# Altium schematic sheet 05-SX1276.SchDoc(05-SX1276)
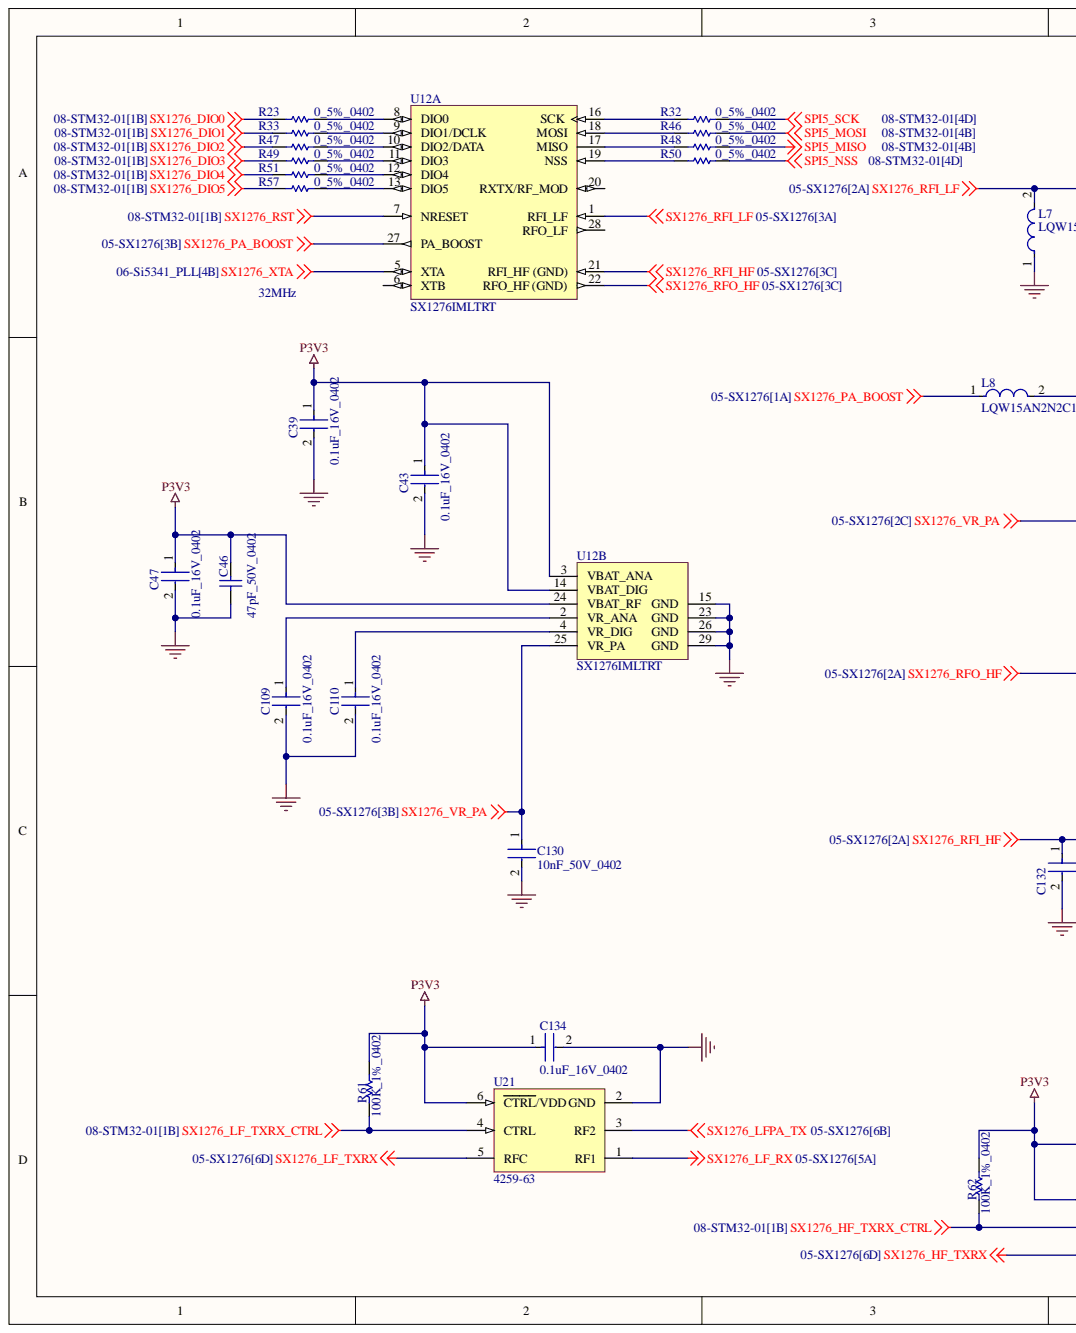
[diagram p0: 05-SX1276.SchDoc, left]
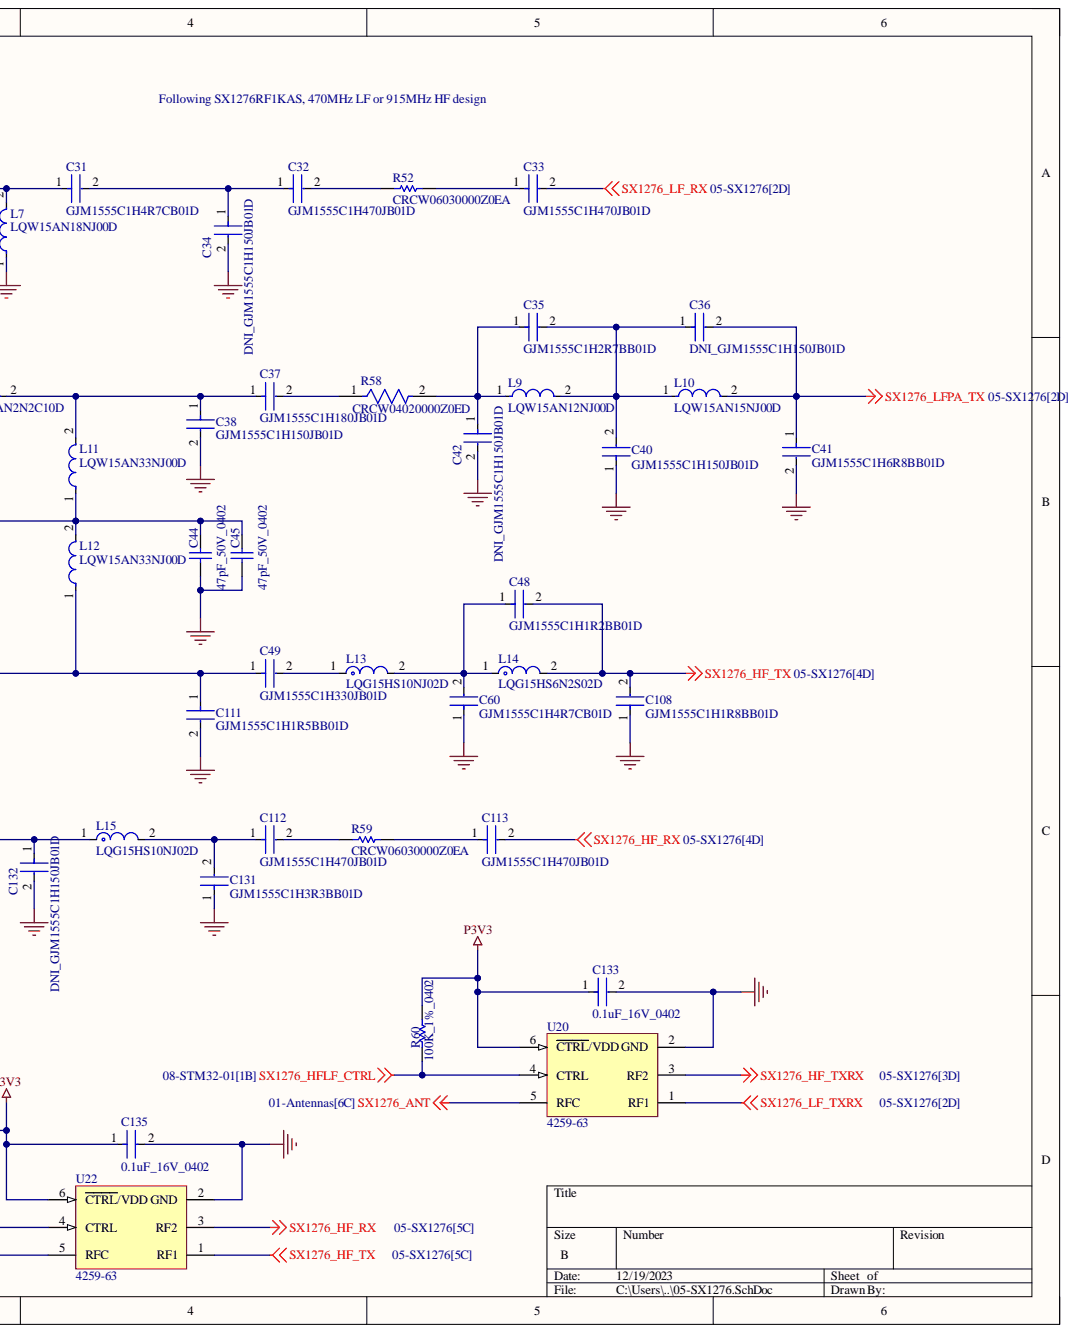
[diagram p1: 05-SX1276.SchDoc, right]

## Parts
C31  GJM1555C1H4R7CB01D  [FP-GJM155-0_05-IPC_B]
C32  GJM1555C1H470JB01D  [FP-GJM155-0_05-MFG]
C33  GJM1555C1H470JB01D  [FP-GJM155-0_05-MFG]
C34  DNI_GJM1555C1H150JB01D  [FP-GJM155-0_05-IPC_A]  (no BOM)
C35  GJM1555C1H2R7BB01D  [FP-GJM155-0_05-IPC_A]
C36  DNI_GJM1555C1H150JB01D  [FP-GJM155-0_05-IPC_A]  (no BOM)
C37  GJM1555C1H180JB01D  [FP-GJM155-0_05-IPC_B]
C38  GJM1555C1H150JB01D  [FP-GJM155-0_05-IPC_A]
C39  0.1uF_16V_0402  [FP-C0402-BB-MFG]
C40  GJM1555C1H150JB01D  [FP-GJM155-0_05-IPC_A]
C41  GJM1555C1H6R8BB01D  [FP-GJM155-0_05-IPC_A]
C42  DNI_GJM1555C1H150JB01D  [FP-GJM155-0_05-IPC_A]  (no BOM)
C43  0.1uF_16V_0402  [FP-C0402-BB-MFG]
C44  47pF_50V_0402  [CAPC1005X55X25LL05T10]
C45  47pF_50V_0402  [CAPC1005X55X25LL05T10]
C46  47pF_50V_0402  [CAPC1005X55X25LL05T10]
C47  0.1uF_16V_0402  [FP-C0402-BB-MFG]
C48  GJM1555C1H1R2BB01D  [FP-GJM155-0_05-IPC_B]
C49  GJM1555C1H330JB01D  [FP-GJM155-0_05-MFG]
C60  GJM1555C1H4R7CB01D  [FP-GJM155-0_05-IPC_B]
C108  GJM1555C1H1R8BB01D  [FP-GJM155-0_05-IPC_B]
C109  0.1uF_16V_0402  [FP-C0402-BB-MFG]
C110  0.1uF_16V_0402  [FP-C0402-BB-MFG]
C111  GJM1555C1H1R5BB01D  [FP-GJM155-0_05-IPC_C]
C112  GJM1555C1H470JB01D  [FP-GJM155-0_05-MFG]
C113  GJM1555C1H470JB01D  [FP-GJM155-0_05-MFG]
C130  10nF_50V_0402  [FP-CL165-IPC_C]
C131  GJM1555C1H3R3BB01D  [FP-GJM155-0_05-IPC_C]
C132  DNI_GJM1555C1H150JB01D  [FP-GJM155-0_05-IPC_A]  (no BOM)
C133  0.1uF_16V_0402  [FP-C0402-BB-MFG]
C134  0.1uF_16V_0402  [FP-C0402-BB-MFG]
C135  0.1uF_16V_0402  [FP-C0402-BB-MFG]
L7  LQW15AN18NJ00D  [FP-LQW15AN_00-W0_6-0_1-MFG]
L8  LQW15AN2N2C10D  [FP-LQW15AN_00-W0_6-0_1-MFG]
L9  LQW15AN12NJ00D  [FP-LQW15AN_00-W0_6-0_1-MFG]
L10  LQW15AN15NJ00D  [FP-LQW15AN_00-W0_6-0_1-MFG]
L11  LQW15AN33NJ00D  [FP-LQW15AN_00-W0_5-0_1-MFG]
L12  LQW15AN33NJ00D  [FP-LQW15AN_00-W0_5-0_1-MFG]
L13  LQG15HS10NJ02D  [FP-LQG15HH_02-IPC_A]
L14  LQG15HS6N2S02D  [FP-LQG15HH_02-IPC_C]
L15  LQG15HS10NJ02D  [FP-LQG15HH_02-IPC_A]
R23  0_5%_0402  [RESC1005X40X25NL05T10]
R32  0_5%_0402  [RESC1005X40X25NL05T10]
R33  0_5%_0402  [RESC1005X40X25NL05T10]
R46  0_5%_0402  [RESC1005X40X25NL05T10]
R47  0_5%_0402  [RESC1005X40X25NL05T10]
R48  0_5%_0402  [RESC1005X40X25NL05T10]
R49  0_5%_0402  [RESC1005X40X25NL05T10]
R50  0_5%_0402  [RESC1005X40X25NL05T10]
R51  0_5%_0402  [RESC1005X40X25NL05T10]
R52  CRCW06030000Z0EA  [RESC1609X50X30NL8T20]
R57  0_5%_0402  [RESC1005X40X25NL05T10]
R58  CRCW04020000Z0ED  [FP-CRCW0402-e3-IPC_C]
R59  CRCW06030000Z0EA  [RESC1609X50X30NL8T20]
R60  100K_1%_0402  [RESC1005X40X25ML05T10]
R61  100K_1%_0402  [RESC1005X40X25ML05T10]
R62  100K_1%_0402  [RESC1005X40X25ML05T10]
U12  SX1276IMLTRT  [SMTC-QFN-28_V]  (parts A,B)
U20  4259-63  [PERE-SC-70-6_M]
U21  4259-63  [PERE-SC-70-6_M]
U22  4259-63  [PERE-SC-70-6_M]

## Nets
GND: C34.2 C38.2 C39.2 C40.1 C41.2 C42.2 C43.2 C44.1 C45.1 C46.1 C47.2 C60.1 C108.1 C109.2 C110.2 C111.2 C130.2 C131.1 C132.2 C133.2 C134.2 C135.2 L7.1 U12.15(GND) U12.23(GND) U12.26(GND) U12.29(GND) U20.2(GND) U21.2(GND) U22.2(GND)
P3V3: C39.1 C43.1 C46.2 C47.1 C133.1 C134.1 C135.1 R60.2 R61.2 R62.2 U12.3(VBAT_ANA) U12.14(VBAT_DIG) U12.24(VBAT_RF) U20.6(CTRL/VDD) U21.6(CTRL/VDD) U22.6(CTRL/VDD)
SPI5_MISO: R48.2
SPI5_MOSI: R46.2
SPI5_NSS: R50.2
SPI5_SCK: R32.2
SX1276_ANT: U20.5(RFC)
SX1276_DIO0: R23.1
SX1276_DIO1: R33.1
SX1276_DIO2: R47.1
SX1276_DIO3: R49.1
SX1276_DIO4: R51.1
SX1276_DIO5: R57.1
SX1276_HF_RX: C113.2 U22.3(RF2)
SX1276_HF_TX: C48.2 C108.2 L14.2 U22.1(RF1)
SX1276_HF_TXRX: U20.3(RF2) U22.5(RFC)
SX1276_HF_TXRX_CTRL: R62.1 U22.4(CTRL)
SX1276_HFLF_CTRL: R60.1 U20.4(CTRL)
SX1276_LF_RX: C33.2 U21.1(RF1)
SX1276_LF_TXRX: U20.1(RF1) U21.5(RFC)
SX1276_LF_TXRX_CTRL: R61.1 U21.4(CTRL)
SX1276_LFPA_TX: C36.2 C41.1 L10.2 U21.3(RF2)
SX1276_PA_BOOST: L8.1 U12.27(PA_BOOST)
SX1276_RFI_HF: C132.1 L15.1 U12.21(RFI_HF_(GND))
SX1276_RFI_LF: C31.1 L7.2 U12.1(RFI_LF)
SX1276_RFO_HF: C49.1 C111.1 L12.1 U12.22(RFO_HF_(GND))
SX1276_RST: U12.7(NRESET)
SX1276_VR_PA: C44.2 C45.2 C130.1 L11.1 L12.2 U12.25(VR_PA)
SX1276_XTA: U12.5(XTA)
NetC31_2: C31.2 C32.1 C34.1
NetC32_2: C32.2 R52.1
NetC33_1: C33.1 R52.2
NetC35_1: C35.1 C42.1 L9.1 R58.2
NetC35_2: C35.2 C36.1 C40.2 L9.2 L10.1
NetC37_1: C37.1 C38.1 L8.2 L11.2
NetC37_2: C37.2 R58.1
NetC48_1: C48.1 C60.2 L13.2 L14.1
NetC49_2: C49.2 L13.1
NetC109_1: C109.1 U12.2(VR_ANA)
NetC110_1: C110.1 U12.4(VR_DIG)
NetC112_1: C112.1 C131.2 L15.2
NetC112_2: C112.2 R59.1
NetC113_1: C113.1 R59.2
NetR23_2: R23.2 U12.8(DIO0)
NetR32_1: R32.1 U12.16(SCK)
NetR33_2: R33.2 U12.9(DIO1/DCLK)
NetR46_1: R46.1 U12.18(MOSI)
NetR47_2: R47.2 U12.10(DIO2/DATA)
NetR48_1: R48.1 U12.17(MISO)
NetR49_2: R49.2 U12.11(DIO3)
NetR50_1: R50.1 U12.19(NSS)
NetR51_2: R51.2 U12.12(DIO4)
NetR57_2: R57.2 U12.13(DIO5)

Not connected: U12 6(XTB) 20(RXTX/RF_MOD) 28(RFO_LF)

## Library data of the parts
C31 C60 = CMP-2008-03859-2: Multi-Layer Ceramic Capacitor 4.7pF C0G  ±0.25pF 0402 Paper T/R; Temperature Coefficient: 30ppm/°C; Voltage: 50V; Capacitance: 4.7pF; Case Code (Imperial): 0402; Case Code (Metric): 1005; Case/Package: 0402; Component Kind: Standard; Depth: 0.5mm; Dielectric: C0G; Height: 0.5mm; Lead Free: Lead Free; Lead Pitch: 0.3mm; Length: 1mm; Library Name: Altium Content Vault; Max Operating Temperature: 125°C; Min Operating Temperature: -55°C; Mount: Surface Mount; Number of Pins: 2; Package Quantity: 10000; Packaging: Tape and Reel; Radiation Hardening: No; REACH SVHC: No SVHC; Termination: SMD/SMT; Thickness: 0.022inch; Voltage Rating: 50V; Voltage Rating (DC): 50V; Width: 0.02inch
C32 C33 C112 C113 = CMP-06035-013708-1: Multi-Layer Ceramic Capacitor 47pF C0G  ±5% 0402 Paper T/R; Voltage Rating (DC): 50V; Capacitance: 47pF; Component Kind: Standard; Dielectric: C0G; Length: 1mm; Library Name: Altium Content Vault; Thickness: 500um; Tolerance: 5%; Width: 500um
C34 C36 C38 C40 C42 C132 = CMP-2008-03804-2: Multi-Layer Ceramic Capacitor 15pF C0G  ±5% 0402 Paper T/R; Temperature Coefficient: 30ppm/°C; Voltage: 50V; Capacitance: 15pF; Case Code (Imperial): 0402; Case Code (Metric): 1005; Case/Package: 0402; Depth: 0.5mm; Dielectric: C0G; Height: 0.5mm; Lead Free: Lead Free; Lead Pitch: 0.3mm; Length: 1mm; Library Name: Altium Content Vault; Max Operating Temperature: 125°C; Min Operating Temperature: -55°C; Mount: Surface Mount; Number of Pins: 2; Package Quantity: 1; Packaging: Tape and Reel; Radiation Hardening: No; REACH SVHC: No SVHC; Termination: SMD/SMT; Thickness: 0.022inch; Tolerance: 5%; Voltage Rating: 50V; Voltage Rating (DC): 50V; Width: 0.02inch
  C38 C40: Component Kind: Standard
C35 = CMP-2008-03840-2: Multi-Layer Ceramic Capacitor 2.7pF C0G  ±0.1pF 0402 Paper T/R; Temperature Coefficient: 30ppm/°C; Voltage: 50V; Capacitance: 2.7pF; Case Code (Imperial): 0402; Case Code (Metric): 1005; Case/Package: 0402; Component Kind: Standard; Depth: 0.5mm; Dielectric: C0G; Height: 0.5mm; Lead Free: Lead Free; Lead Pitch: 0.3mm; Length: 1mm; Library Name: Altium Content Vault; Max Operating Temperature: 125°C; Min Operating Temperature: -55°C; Mount: Surface Mount; Number of Pins: 2; Package Quantity: 10000; Packaging: Tape and Reel; Radiation Hardening: No; REACH SVHC: No SVHC; Termination: SMD/SMT; Thickness: 0.022inch; Voltage Rating: 50V; Voltage Rating (DC): 50V; Width: 0.02inch
C37 = CMP-2008-03807-2: Multi-Layer Ceramic Capacitor 18pF C0G  ±5% 0402 Paper T/R; Voltage: 50V; Capacitance: 18pF; Case Code (Imperial): 0402; Case Code (Metric): 1005; Case/Package: 0402; Component Kind: Standard; Depth: 0.5mm; Dielectric: C0G; Height: 0.5mm; Lead Free: Lead Free; Length: 1mm; Library Name: Altium Content Vault; Max Operating Temperature: 125°C; Min Operating Temperature: -55°C; Mount: Surface Mount; Package Quantity: 1; Packaging: Tape and Reel; Radiation Hardening: No; Termination: SMD/SMT; Thickness: 0.022inch; Tolerance: 5%; Voltage Rating: 50V; Voltage Rating (DC): 50V; Width: 0.02inch
C39 C43 C47 C109 C110 C133-C135 = CMP-1034-02068-3: CAP CER 0.1UF 16V 5% X7R 0402; Manufacturer: Kemet; Manufacturer Part Number: C0402C104J4RACTU; Capacitance: 0.10 μF; Material: Ceramic; Temperature Coefficient: 15%; Tolerance: 0.05; Value: 0.10 μF; Automotive: No; Category: Cap; Component Kind: Standard; ComponentLink2Description: Datasheet; ComponentLink2URL: https://content.kemet.com/datasheets/KEM_C1002_X7R_SMD.pdf; Device Class L1: Passive Components; Device Class L2: Capacitors; Device Class L3: Ceramic Capacitors; Digikey Description: CAP CER 0.1UF 16V 5% X7R 0402; Height: 0.55mm; Ipc Land Pattern Name: CAPC10050X50; Lead Free: Yes; Library Name: Altium Content Vault; Mouser Description: CAP CER 0.1UF 16V X7R 0402; Octopart: https://octopart.com/c0402c104j4ractu-kemet-12573893; Package: C0402-BB; RoHS: TRUE; Temperature: -55°C to +125°C; Temperature Characteristic: X7R; Temperature Range High: +125°C; Temperature Range Low: -55°C; Voltage Rating: 16 V
C41 = CMP-2008-03772-2: Multi-Layer Ceramic Capacitor 6.8pF C0G  ±0.1pF 0402 Paper T/R; Voltage Rating: 50V; Capacitance: 6.8pF; Case Code (Imperial): 0402; Case Code (Metric): 1005; Case/Package: 0402; Component Kind: Standard; Depth: 0.5mm; Dielectric: C0G; Height: 0.5mm; Lead Free: Lead Free; Length: 1mm; Library Name: Altium Content Vault; Max Operating Temperature: 125°C; Min Operating Temperature: -55°C; Mount: Surface Mount; Package Quantity: 10000; Packaging: Tape and Reel; Radiation Hardening: No; Termination: SMD/SMT; Thickness: 0.022inch; Voltage Rating (DC): 50V; Width: 0.02inch
C44-C46 = CMP-2008-04368-1: Manufacturer: Murata; Part Number: GRM1555C1H470JA01D; Component Kind: Standard; Library Name: Altium Content Vault
C48 = CMP-2008-03813-2: Multi-Layer Ceramic Capacitor 1.2pF C0G  ±0.1pF 0402 Paper T/R; Temperature Coefficient: 30ppm/°C; Voltage: 50V; Capacitance: 1.2pF; Case Code (Imperial): 0402; Case Code (Metric): 1005; Case/Package: 0402; Component Kind: Standard; Depth: 0.5mm; Dielectric: C0G; Height: 0.5mm; Lead Free: Lead Free; Lead Pitch: 0.3mm; Length: 1mm; Library Name: Altium Content Vault; Max Operating Temperature: 125°C; Min Operating Temperature: -55°C; Mount: Surface Mount; Number of Pins: 2; Package Quantity: 10000; Packaging: Tape and Reel; Radiation Hardening: No; REACH SVHC: No SVHC; Termination: SMD/SMT; Thickness: 0.022inch; Voltage Rating: 50V; Voltage Rating (DC): 50V; Weight: 2.3E-05oz; Width: 0.5mm
C49 = CMP-06035-057988-1: Multi-Layer Ceramic Capacitor 33pF C0G  ±5% 0402 Paper T/R; Voltage Rating (DC): 50V; Capacitance: 33pF; Component Kind: Standard; Dielectric: C0G; Length: 1mm; Library Name: Altium Content Vault; Thickness: 500um; Tolerance: 5%; Width: 500um
C108 = CMP-2008-03825-2: Multi-Layer Ceramic Capacitor 1.8pF C0G  ±0.1pF 0402 Paper T/R; Voltage: 50V; Capacitance: 1.8pF; Case Code (Imperial): 0402; Case Code (Metric): 1005; Case/Package: 0402; Component Kind: Standard; Depth: 0.5mm; Dielectric: C0G; Height: 0.5mm; Lead Free: Lead Free; Lead Pitch: 0.3mm; Length: 1mm; Library Name: Altium Content Vault; Max Operating Temperature: 125°C; Min Operating Temperature: -55°C; Mount: Surface Mount; Number of Pins: 2; Package Quantity: 10000; Packaging: Tape and Reel; Radiation Hardening: No; REACH SVHC: No SVHC; Termination: SMD/SMT; Thickness: 0.022inch; Voltage Rating: 50V; Voltage Rating (DC): 50V; Width: 0.5mm
C111 = CMP-2008-03820-2: Multi-Layer Ceramic Capacitor 1.5pF C0G  ±0.1pF 0402 Paper T/R; Temperature Coefficient: 30ppm/°C; Voltage: 50V; Capacitance: 1.5pF; Case Code (Imperial): 0402; Case Code (Metric): 1005; Case/Package: 0402; Component Kind: Standard; Construction: Flat; Depth: 0.5mm; Dielectric: C0G; Height: 0.5mm; Lead Free: Lead Free; Lead Pitch: 0.3mm; Length: 1mm; Library Name: Altium Content Vault; Max Operating Temperature: 125°C; Min Operating Temperature: -55°C; Mount: Surface Mount; Number of Pins: 2; Package Quantity: 10000; Packaging: Tape and Reel; Radiation Hardening: No; REACH SVHC: No SVHC; Series: GJM; Termination: SMD/SMT; Thickness: 0.022inch; Voltage Rating: 50V; Voltage Rating (DC): 50V; Width: 0.5mm
C130 = CMP-13271-000387-1: Cap Ceramic 10nF 50V X7R ±10% SMD 0402 +125°C Paper T/R; Capacitance: 10nF; Case Code (Imperial): 0402; Case Code (Metric): 1005; Case/Package: 0402; Component Kind: Standard; Contact Plating: Tin; Depth: 0.5mm; Dielectric: X7R; Height: 0.5mm; Lead Free: Lead Free; Length: 1mm; Library Name: Altium Content Vault; Material: Ceramic; Max Operating Temperature: 125°C; Min Operating Temperature: -55°C; Mount: Surface Mount; Package Quantity: 1; Packaging: Tape and Reel; Radiation Hardening: No; Thickness: 0.022inch; Tolerance: 10%; Voltage: 50V; Voltage Rating: 50V; Voltage Rating (DC): 50V; Width: 0.02inch
C131 = CMP-2008-03846-2: Multi-Layer Ceramic Capacitor 3.3pF C0G  ±0.1pF 0402 Paper T/R; Voltage: 50V; Capacitance: 3.3pF; Case Code (Imperial): 0402; Case Code (Metric): 1005; Case/Package: 0402; Component Kind: Standard; Depth: 0.5mm; Dielectric: C0G; Height: 0.5mm; Lead Free: Lead Free; Lead Pitch: 0.3mm; Length: 1mm; Library Name: Altium Content Vault; Max Operating Temperature: 125°C; Min Operating Temperature: -55°C; Mount: Surface Mount; Number of Pins: 2; Package Quantity: 1; Packaging: Tape and Reel; Radiation Hardening: No; REACH SVHC: No SVHC; Termination: SMD/SMT; Thickness: 0.022inch; Voltage Rating: 50V; Voltage Rating (DC): 50V; Width: 0.5mm
L7 = CMP-06042-006916-1: Wire Wound RF Inductor 18nH ±5% 370mA 0.27Ω 0402 (1005); Core Material: Air; DC Resistance (DCR): 270mR; Q Factor: 25; Self Resonant Frequency: 4.5GHz; Shielding: Unshielded; Test Frequency: 100MHz; Case Code (Imperial): 0402; Case Code (Metric): 1005; Case/Package: 0402; Component Kind: Standard; Current Rating: 370mA; DC Current: 370mA; Depth: 0.7mm; Height: 0.5mm; Inductance: 18nH; Lead Free: Lead Free; Length: 1mm; Library Name: Altium Content Vault; Max DC Current: 370mA; Max Operating Temperature: 125°C; Military Standard: Not; Min Operating Temperature: -55°C; Mount: Surface Mount; Package Quantity: 10000; Packaging: Tape and Reel; Radiation Hardening: No; Resistor Type: Wirewound; Termination: SMD/SMT; Tolerance: 5%; Weight: 2.8E-05oz; Width: 0.02inch
L8 = CMP-06042-008786-1: Wire Wound RF Inductor 2.2nH ±0.2nH 1000mA 0.027Ω 0402 (1005); Core Material: Air; Current Rating: 1A; DC Resistance (DCR): 27mR; Q Factor: 25; Self Resonant Frequency: 14GHz; Shielding: Unshielded; Test Frequency: 100MHz; Case Code (Imperial): 0402; Case Code (Metric): 1005; Case/Package: 0402; Component Kind: Standard; DC Current: 1A; Depth: 0.7mm; Height: 0.5mm; Inductance: 2.2nH; Lead Free: Lead Free; Length: 1mm; Library Name: Altium Content Vault; Max DC Current: 1A; Max Operating Temperature: 125°C; Military Standard: Not; Min Operating Temperature: -55°C; Mount: Surface Mount; Number of Pins: 2; Package Quantity: 10000; Packaging: Tape and Reel; Radiation Hardening: No; REACH SVHC: No SVHC; Termination: SMD/SMT; Weight: 2.8E-05oz; Width: 0.5mm
L9 = CMP-06042-005424-1: Wire Wound RF Inductor 12nH ±5% 500mA 0.14Ω 0402 (1005); Core Material: Air; DC Resistance (DCR): 140mR; Q Factor: 30; Self Resonant Frequency: 5.5GHz; Shielding: Unshielded; Test Frequency: 100MHz; Case Code (Imperial): 0402; Case Code (Metric): 1005; Case/Package: 0402; Component Kind: Standard; Current Rating: 500mA; DC Current: 500mA; Depth: 0.7mm; Height: 0.02inch; Inductance: 12nH; Lead Free: Lead Free; Length: 1.1mm; Library Name: Altium Content Vault; Max DC Current: 500mA; Max Operating Temperature: 125°C; Military Standard: Not; Min Operating Temperature: -55°C; Mount: Surface Mount; Package Quantity: 10000; Packaging: Tape and Reel; Radiation Hardening: No; Resistor Type: Wirewound; Termination: SMD/SMT; Tolerance: 5%; Weight: 2.8E-05oz; Width: 0.5mm
L10 = CMP-2000-06595-2: Wire Wound RF Inductor 15nH ±5% 460mA 0.16Ω 0402 (1005); Core Material: Air; DC Resistance (DCR): 160mR; Q Factor: 30; Self Resonant Frequency: 5GHz; Shielding: Unshielded; Test Frequency: 100MHz; Case Code (Imperial): 0402; Case Code (Metric): 1005; Case/Package: 0402; Component Kind: Standard; Current Rating: 460mA; DC Current: 460mA; Depth: 0.7mm; Height: 0.5mm; Inductance: 15nH; Lead Free: Lead Free; Length: 1mm; Library Name: Altium Content Vault; Max DC Current: 460mA; Max Operating Temperature: 125°C; Military Standard: Not; Min Operating Temperature: -55°C; Mount: Surface Mount; Package Quantity: 10000; Packaging: Tape and Reel; Radiation Hardening: No; Resistor Type: Wirewound; Termination: SMD/SMT; Tolerance: 5%; Weight: 2.8E-05oz; Width: 0.02inch
L11 L12 = CMP-06042-007456-1: Wire Wound RF Inductor 33nH ±5% 260mA 0.63Ω 0402 (1005); Core Material: Air; DC Resistance (DCR): 630mR; Q Factor: 25; Self Resonant Frequency: 3.2GHz; Shielding: Unshielded; Test Frequency: 100MHz; Case Code (Imperial): 0402; Case Code (Metric): 1005; Case/Package: 0402; Component Kind: Standard; Current Rating: 260mA; DC Current: 260mA; Depth: 0.6mm; Height: 0.5mm; Inductance: 33nH; Lead Free: Lead Free; Length: 1mm; Library Name: Altium Content Vault; Max DC Current: 260mA; Max Operating Temperature: 125°C; Military Standard: Not; Min Operating Temperature: -55°C; Mount: Surface Mount; Package Quantity: 10000; Packaging: Tape and Reel; Radiation Hardening: No; Resistor Type: Wirewound; Termination: SMD/SMT; Tolerance: 5%; Weight: 2.8E-05oz; Width: 0.5mm
L13 L15 = CMP-06042-014117-1: Multilayer type RF Inductor 10nH ±5% 0.26Ω 500mA 0402; Core Material: Air; Current Rating: 300mA; DC Resistance (DCR): 260mR; Q Factor: 8; Self Resonant Frequency: 3.4GHz; Shielding: Unshielded; Test Frequency: 100MHz; Case Code (Imperial): 0402; Case Code (Metric): 1005; Case/Package: 0402; Component Kind: Standard; DC Current: 300mA; Depth: 0.55mm; Height: 0.5mm; Height - Seated (Max): 0.022inch; Inductance: 10nH; Lead Free: Lead Free; Length: 0.039inch; Library Name: Altium Content Vault; Max DC Current: 300mA; Max Operating Temperature: 125°C; Military Standard: Not; Min Operating Temperature: -55°C; Mount: Surface Mount; Package Quantity: 10000; Packaging: Tape and Reel; Radiation Hardening: No; Termination: SMD/SMT; Tolerance: 5%; Width: 0.5mm
L14 = CMP-06042-008294-1: Multilayer type RF Inductor 6.2nH ±0.3nH 0.2Ω 600mA 0402; Core Material: Air; Current Rating: 300mA; DC Resistance (DCR): 220mR; Q Factor: 8; Self Resonant Frequency: 4.5GHz; Shielding: Unshielded; Test Frequency: 100MHz; Case Code (Imperial): 0402; Case Code (Metric): 1005; Case/Package: 0402; Component Kind: Standard; DC Current: 300mA; Depth: 0.55mm; Height: 0.5mm; Height - Seated (Max): 0.022inch; Inductance: 6.2nH; Lead Free: Lead Free; Length: 1mm; Library Name: Altium Content Vault; Max DC Current: 300mA; Max Operating Temperature: 125°C; Military Standard: Not; Min Operating Temperature: -55°C; Mount: Surface Mount; Package Quantity: 10000; Packaging: Tape and Reel; Radiation Hardening: No; Termination: SMD/SMT; Width: 0.02inch
R23 R32 R33 R46-R51 R57 = CMP-2002-06457-1: Manufacturer: Panasonic; Part Number: ERJ-U020R00X; Component Kind: Standard; Library Name: Altium Content Vault
R52 R59 = CMP-2000-03816-5: Chip Resistor, 0 Ohm, Jumper, 100 mW, -55 to 155 degC, 0603 (1608 Metric), RoHS, Tape and Reel; Manufacturer: Vishay Semiconductor; Voltage Rating: 75 V; Component Kind: Standard; ComponentLink1Description: Manufacturer URL; ComponentLink1URL: http://www.vishay.com/; ComponentLink2Description: Datasheet; ComponentLink2URL: http://www.vishay.com/docs/20035/dcrcwe3.pdf; DatasheetVersion: 06/2012; Height: 0.45 mm; Length: 1.55 mm; Library Name: Altium Content Vault; Max Operating Temperature: 155 degC; Min Operating Temperature: -55 degC; Mount: Surface Mount; Mounting Technology: Surface Mount; Package Quantity: 5000; PackageDescription: 2-Pin Surface Mount Device, Body 1.575 x 0.85 mm; PackageReference: 0603; Packaging: Tape and Reel; Pins: 2; REACH SVHC: Unknown; RoHSCompliant: true
R58 = CMP-2002-08122-2: RES Thick Film, 0Ω, 0.063W, 0402; Max Power Dissipation: 63mW; Resistance: 0mR; Temperature Coefficient: 100ppm/°C; Tolerance: 5%; Voltage Rating: 50V; Case Code (Imperial): 0402; Case Code (Metric): 1005; Case/Package: 0402; Component Kind: Standard; Composition: Thick Film; Contact Plating: Tin; Depth: 0.5mm; Features: Automotive AEC-Q200; Height: 0.35mm; Lead Free: Lead Free; Length: 1mm; Library Name: Altium Content Vault; Max Operating Temperature: 155°C; Military Standard: Not; Min Operating Temperature: -55°C; Mount: Surface Mount; Number of Terminations: 2; Package Quantity: 1; Packaging: Tape and Reel; Power Rating: 63mW; Radiation Hardening: No; Termination: SMD/SMT; Voltage Rating (DC): 50V; Weight: 2.3E-05oz; Width: 0.5mm
R60-R62 = CMP-2002-07852-1: Manufacturer: Yageo; Part Number: RC0402FR-13100KL; Component Kind: Standard; Library Name: Altium Content Vault
U12 = CMP-2000-05356-1: Low Power Long Range Transceiver, 137 to 1020 MHz, -40 to 85 degC, 28-Pin QFN, RoHS, Tape and Reel; Manufacturer: Semtech; Current - Receiving: 10.8mA~12mA; Current - Transmitting: 20mA~120mA; Data Rate (Max): 300kbps; Design Resources: SX127xReferenceDesignOverviewDevelopmentToolSelector; Featured Product: SX1276LongRangeTransceiver; Frequency: 137MHz~1.02GHz; GPIO: 6; Mfg Application Notes: LoRaModulation:CrystalOscillatorGuidanceSX12xxSoftwareImplementationofDataWhiteningandCRCSX127xLoRaLowEnergyDesignGuideSX127xLoRaModemDesignersGuide; Modulation: FSK,GFSK,GMSK,MSK,OOK; Online Catalog: SX1276,SX1277andSX1278; Other Names: SX1276IMLTRTTR; PCN Design/Specification: CopperWire25/Mar/2014; Power - Output: 20dBm; Protocol: LoRa™; Sensitivity: -148dBm; Serial Interfaces: SPI; Voltage - Supply: 1.8V~3.7V; Category: RF/IFandRFID; Component Kind: Standard; Datasheet URL: http://www.semtech.com/images/datasheet/sx1276.pdf; Datasheet Version: Rev. 4, 03/2015; Family: RFTransceiverICs; Library Name: Altium Content Vault; Manufacturer URL: http://www.semtech.com/; Memory Size: -; Operating Temperature: -40degC~85degC; Package / Case: 28-VQFNExposedPad; Package Description: 28-Pin Quad Flat No-lead Package, Body 6 x 6 mm, Pitch 0.65 mm; Package Reference: QFN-28; Packaging: Tape&Reel(TR); RF Family/Standard: 802.15.4; Series: -; Standard Package: 3,000; Type: TxRx+MCU
U20-U22 = CMP-2000-05298-1: IC RF Switch SPDT, 50 Ohm, 6-Pin SC70, RoHS, Tape and Reel; Manufacturer: Peregrine Semiconductor; Frequency - Lower: 10MHz; Frequency - Upper: 3GHz; IIP3: 55dBm(typ); Impedance: 50Ohm; Insertion Loss @ Frequency: 0.5dB@2GHz; Isolation @ Frequency: 20dB@2GHz(typ); Online Catalog: PE4259; Other Names: 1046-1011-24259-63-ND425963PE4259PE4259SCBC-ZPE4259SCBC-Z-ND; P1dB: 29dBm(typ)IP1dB; RF Type: GeneralPurpose; Topology: Reflective; Voltage - Supply: 1.8V~3.3V; Category: RF/IFandRFID; Circuit: SPDT; Component Kind: Standard; Datasheet URL: https://datasheet.ciiva.com/15100/getdatasheetpartid-685660-15100501.pdf; Datasheet Version: Jan-11; Family: RFSwitches; Features: Single/DualLineControl; Library Name: Altium Content Vault; Manufacturer URL: http://www.psemi.com/; Operating Temperature: -40degC~85degC; Package / Case: 6-TSSOP,SC-88,SOT-363; Package Description: 6-Pin Small Outline Transistor, Body 2.05 x 1.25 mm, Pitch 0.65 mm; Package Reference: SC-70-6; Packaging: Tape&Reel(TR); Series: UltraCMOS; Standard Package: 3,000; Supplier Device Package: SC-70-6
